# Altium schematic sheet RS485-Bus.SchDoc(RS485-Bus)
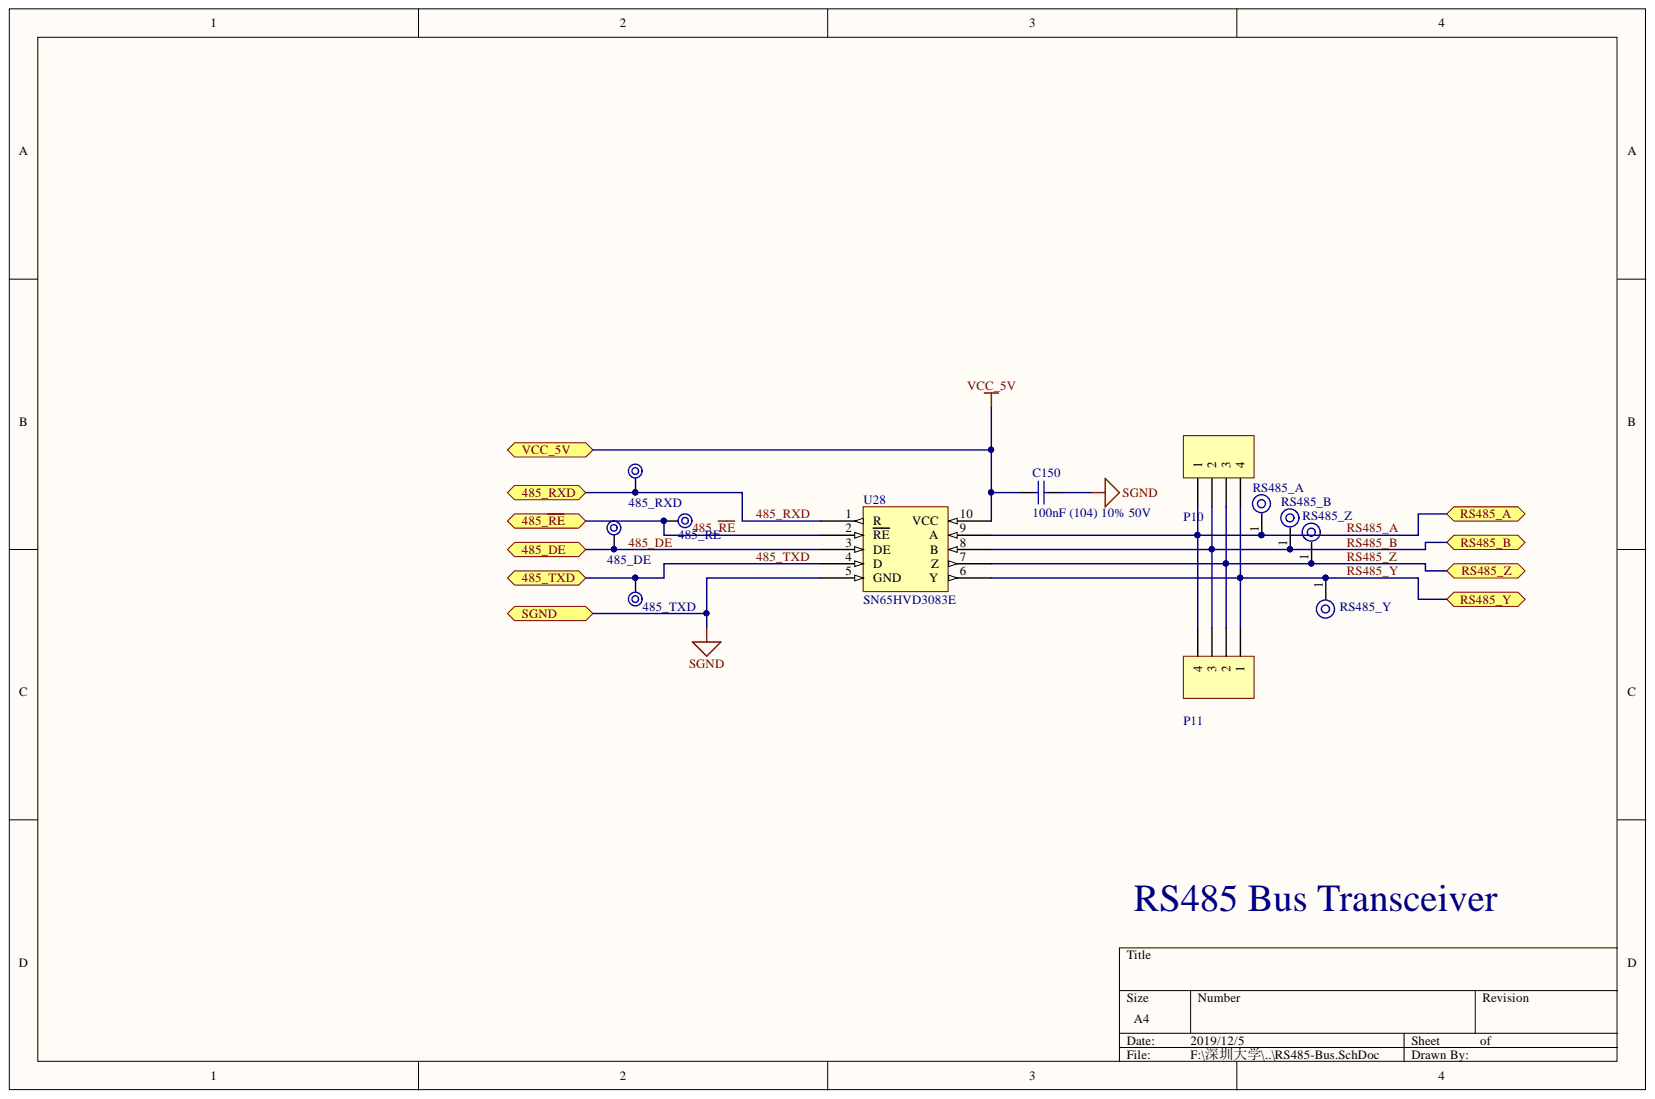
[diagram p0: RS485-Bus.SchDoc]

## Parts
485_DE  TP_Surface  [TEST_POINT_ME]  (value not drawn)
485_RE  TP_Surface  [TEST_POINT_ME]  (value not drawn)
485_RXD  TP_Surface  [TEST_POINT_ME]  (value not drawn)
485_TXD  TP_Surface  [TEST_POINT_ME]  (value not drawn)
C150  100nF (104) 10% 50V  [0603_C]
P10  Header 4  [HDR4X1_B1]  (value not drawn)
P11  Header 4  [HDR4X1_B1]  (value not drawn)
RS485_A  TestPoint  [TestPoint]  (value not drawn)
RS485_B  TestPoint  [TestPoint]  (value not drawn)
RS485_Y  TestPoint  [TestPoint]  (value not drawn)
RS485_Z  TestPoint  [TestPoint]  (value not drawn)
U28  SN65HVD3083E  [SOP127P600-8N]

## Ports
485_DE, 485_RXD, 485_TXD, 485_~{RE}, RS485_A, RS485_B, RS485_Y, RS485_Z, SGND, VCC_5V

## Nets
485_DE: 485_DE.1 U28.3(DE) ; port 485_DE
485_~{RE}: 485_RE.1 U28.2(RE) ; port 485_~{RE}
485_RXD: 485_RXD.1 U28.1(R) ; port 485_RXD
485_TXD: 485_TXD.1 U28.4(D) ; port 485_TXD
RS485_A: P10.1 P11.4 RS485_A.1 U28.9(A) ; port RS485_A
RS485_B: P10.2 P11.3 RS485_B.1 U28.8(B) ; port RS485_B
RS485_Y: P10.4 P11.1 RS485_Y.1 U28.6(Y) ; port RS485_Y
RS485_Z: P10.3 P11.2 RS485_Z.1 U28.7(Z) ; port RS485_Z
SGND: C150.2 U28.5(GND) ; port SGND
VCC_5V: C150.1 U28.10(VCC) ; port VCC_5V

## Library data of the parts
C150 = 0603 100nF (104) 10% 50V: 贴片电容; SuppliersPartNumber: C14663; Supplier: LC; Package: 0603; Library Name: JLC Library.IntLib; ComponentLink1URL: http://www.szlcsc.com/product/details_15331.html; ComponentLink1Description: 供应商链接; Component Kind: Standard
P10 P11 = Header 4: 接插件; LatestRevisionNote: Re-released for DXP Platform.; LatestRevisionDate: 17-Jul-2002; Component Kind: Standard; Publisher: Altium Limited; Library Name: 接插件集成库.IntLib
RS485_A RS485_B RS485_Y RS485_Z = TestPoint: 信号测试点; Component Kind: Standard; Library Name: MPLM_Driver_V10A1.IntLib
U28 = SN65HVD3083E: RS-485 FULL-DUPLEX DRIVERS 1 Mbps DGS, DGSR 10-pin; Component Kind: Standard; Library Name: MPLM_Driver_V10A1.IntLib
485_DE 485_RE 485_RXD 485_TXD = TP_Surface: Component Kind: Standard; Library Name: PSRM_Sensorless_V2.0模块.IntLib
